# Altium schematic sheet LORA_NANO.SchDoc
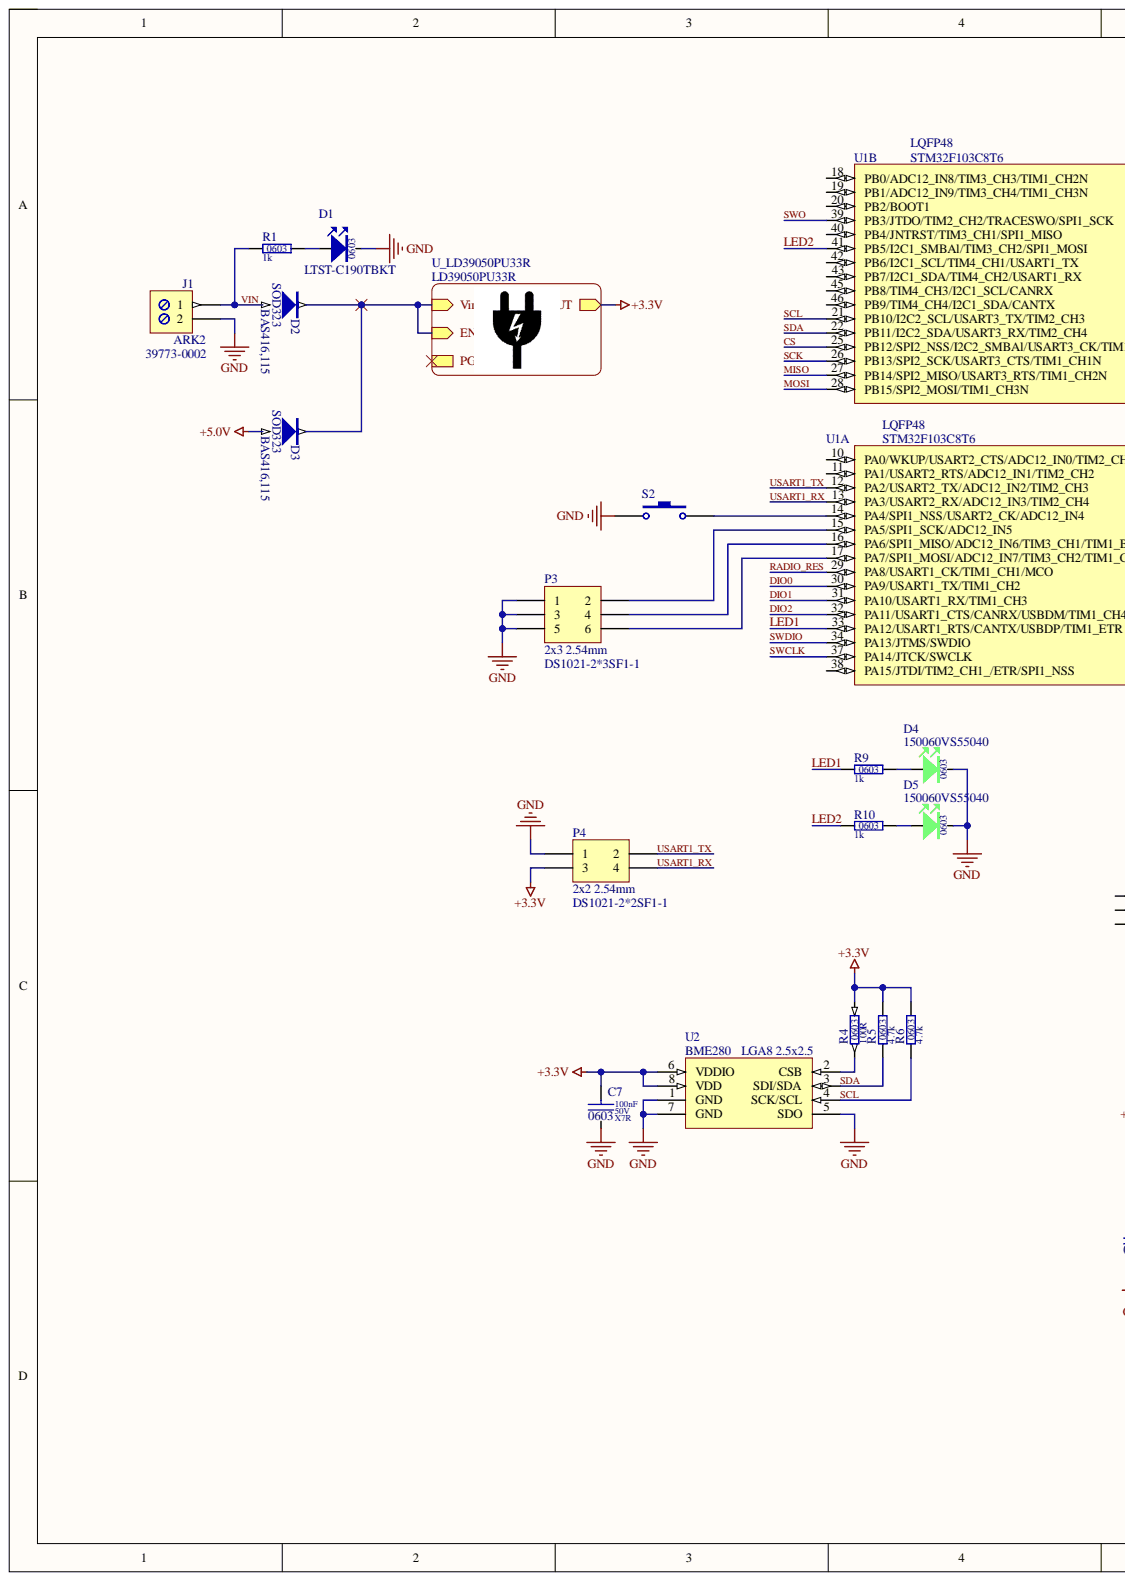
[diagram p0: LORA_NANO.SchDoc, left]
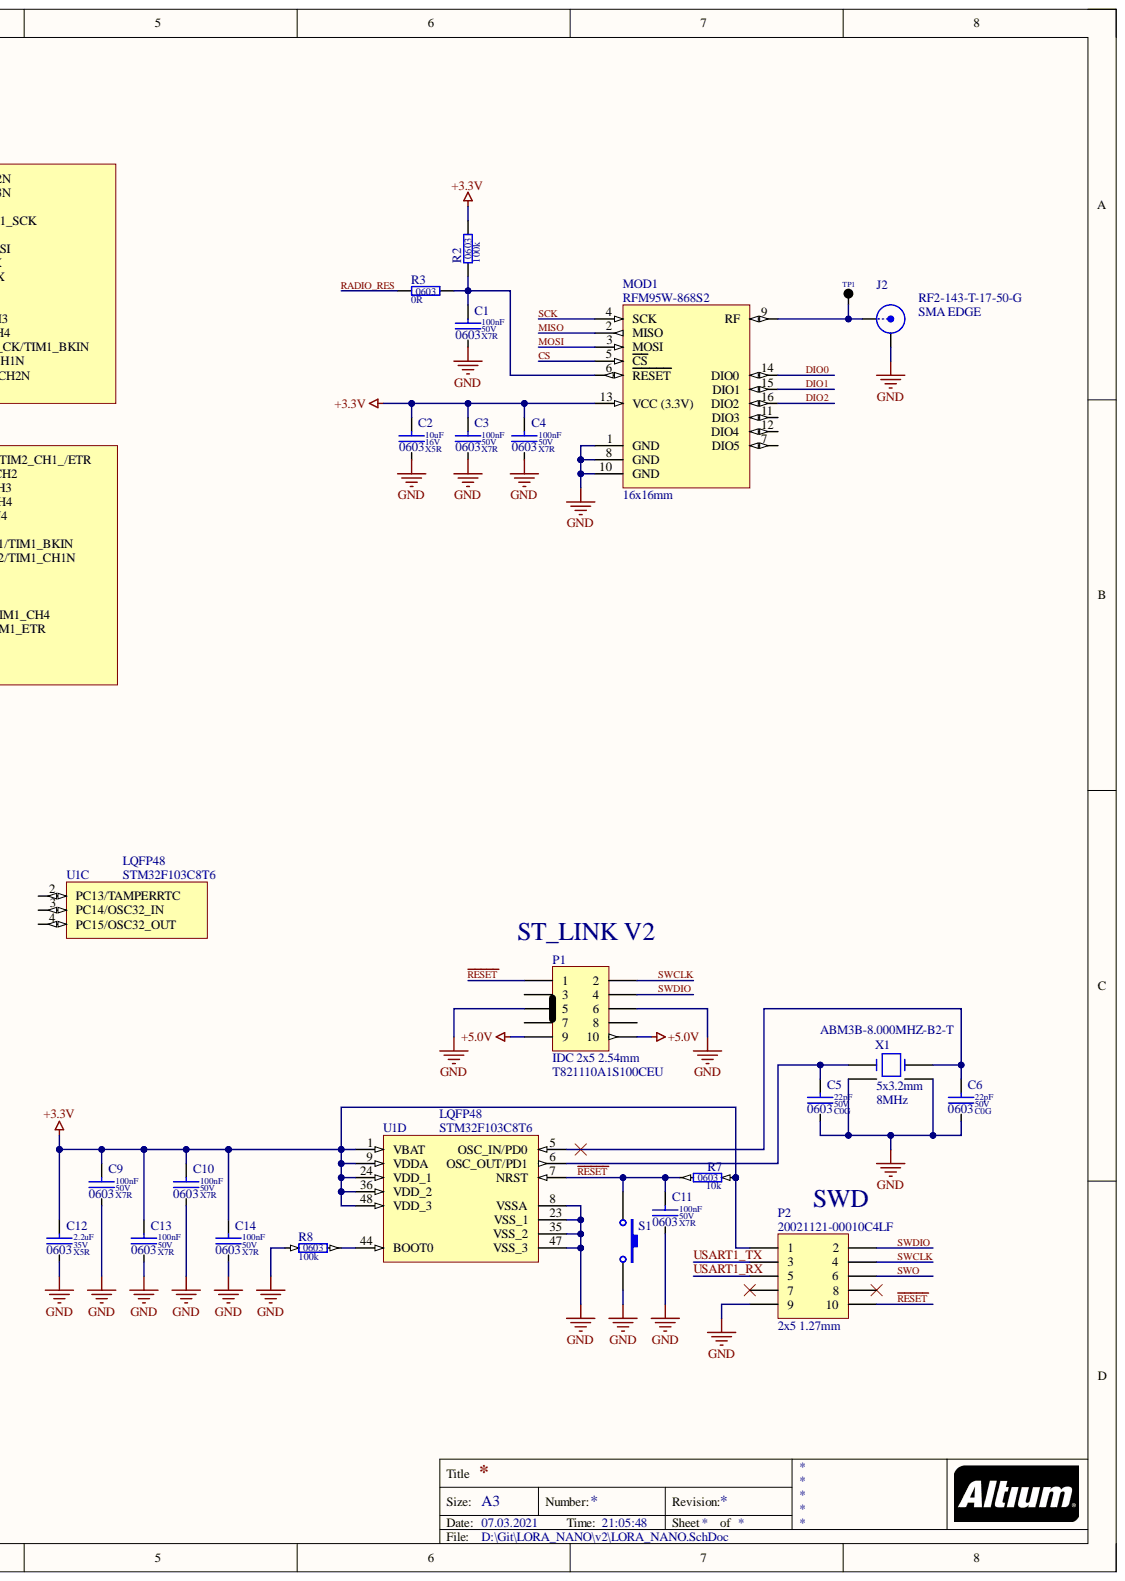
[diagram p1: LORA_NANO.SchDoc, right]

## Parts
C1  value 100nF  Dielectric: X7R  SCH_Footprint: 0603  Voltage: 50V  [C_0603_1608]  (hidden comment: Ceramic X7R)
C2  value 10uF  Dielectric: X5R  SCH_Footprint: 0603  Voltage: 16V  [C_0603_1608]  (hidden comment: Ceramic X5R)
C3  value 100nF  Dielectric: X7R  SCH_Footprint: 0603  Voltage: 50V  [C_0603_1608]  (hidden comment: Ceramic X7R)
C4  value 100nF  Dielectric: X7R  SCH_Footprint: 0603  Voltage: 50V  [C_0603_1608]  (hidden comment: Ceramic X7R)
C5  value 22pF  Dielectric: C0G  SCH_Footprint: 0603  Voltage: 50V  [C_0603_1608]  (hidden comment: Ceramic C0G)
C6  value 22pF  Dielectric: C0G  SCH_Footprint: 0603  Voltage: 50V  [C_0603_1608]  (hidden comment: Ceramic C0G)
C7  value 100nF  Dielectric: X7R  SCH_Footprint: 0603  Voltage: 50V  [C_0603_1608]  (hidden comment: Ceramic X7R)
C9  value 100nF  Dielectric: X7R  SCH_Footprint: 0603  Voltage: 50V  [C_0603_1608]  (hidden comment: Ceramic X7R)
C10  value 100nF  Dielectric: X7R  SCH_Footprint: 0603  Voltage: 50V  [C_0603_1608]  (hidden comment: Ceramic X7R)
C11  value 100nF  Dielectric: X7R  SCH_Footprint: 0603  Voltage: 50V  [C_0603_1608]  (hidden comment: Ceramic X7R)
C12  value 2.2uF  Dielectric: X5R  SCH_Footprint: 0603  Voltage: 35V  [C_0603_1608]  (hidden comment: Ceramic X5R)
C13  value 100nF  Dielectric: X7R  SCH_Footprint: 0603  Voltage: 50V  [C_0603_1608]  (hidden comment: Ceramic X7R)
C14  value 100nF  Dielectric: X7R  SCH_Footprint: 0603  Voltage: 50V  [C_0603_1608]  (hidden comment: Ceramic X7R)
D1  value LTST-C190TBKT  Manufacturer Part Number: LTST-C190TBKT  SCH_Footprint: 0603  [OL_0603_1608]  (hidden comment: Blue Led)
D2  BAS416  value BAS416,115  Manufacturer Part Number: BAS416,115  [SOD323]
D3  BAS416  value BAS416,115  Manufacturer Part Number: BAS416,115  [SOD323]
D4  value 150060VS55040  Manufacturer Part Number: 150060VS55040  SCH_Footprint: 0603  [OL_0603_1608]  (hidden comment: Green LED 0603)
D5  value 150060VS55040  Manufacturer Part Number: 150060VS55040  SCH_Footprint: 0603  [OL_0603_1608]  (hidden comment: Green LED 0603)
J1  ARK2  value 39773-0002  Manufacturer Part Number: 39773-0002  [J_ARK2_2.54]
J2  SMA EDGE  value RF2-143-T-17-50-G  Manufacturer Part Number: RF2-143-T-17-50-G  [J_SMA_EDGE_6.25]
MOD1  value RFM95W-868S2  Manufacturer Part Number: RFM95W-868S2  SCH_Footprint: 16x16mm  [MOD_RFM95]  (hidden comment: RFM95(W) - Low Power Long Range Transceiver Module + LoRa)
P1  IDC  value T821110A1S100CEU  Manufacturer Part Number: T821110A1S100CEU  SCH_Footprint: IDC 2x5 2.54mm  [P_IDC_2x5_2.54]
P2  value 20021121-00010C4LF  Manufacturer Part Number: 20021121-00010C4LF  SCH_Footprint: 2x5 1.27mm  [P_HEADER_2x5_1.27]  (hidden comment: Jtag)
P3  value DS1021-2*3SF1-1  Manufacturer Part Number: DS1021-2*3SF1-1  SCH_Footprint: 2x3 2.54mm  [P_HEADER_2x3_2.54]  (hidden comment: Goldpin male)
P4  value DS1021-2*2SF1-1  Manufacturer Part Number: DS1021-2*2SF1-1  SCH_Footprint: 2x2 2.54mm  [P_HEADER_2x2_2.54]  (hidden comment: Goldpin male)
R1  value 1k  SCH_Footprint: 0603  [R_0603_1608]  (hidden comment: Resistor)
R2  value 100k  SCH_Footprint: 0603  [R_0603_1608]  (hidden comment: Resistor)
R3  value 0R  SCH_Footprint: 0603  [R_0603_1608]  (hidden comment: Resistor)
R4  value 100R  SCH_Footprint: 0603  [R_0603_1608]  (hidden comment: Resistor)
R5  value 4.7k  SCH_Footprint: 0603  [R_0603_1608]  (hidden comment: Resistor)
R6  value 4.7k  SCH_Footprint: 0603  [R_0603_1608]  (hidden comment: Resistor)
R7  value 10k  SCH_Footprint: 0603  [R_0603_1608]  (hidden comment: Resistor)
R8  value 100k  SCH_Footprint: 0603  [R_0603_1608]  (hidden comment: Resistor)
R9  value 1k  SCH_Footprint: 0603  [R_0603_1608]  (hidden comment: Resistor)
R10  value 1k  SCH_Footprint: 0603  [R_0603_1608]  (hidden comment: Resistor)
S1  3x6mm  [S_TACTSWITCH3x6]  (value not drawn)
S2  3x6mm  [S_TACTSWITCH3x6]  (value not drawn)
TP1  Single pin  [TP_1PIN]  (value not drawn)
U1  STM32F103C8T6  SCH_Footprint: LQFP48  [LQFP48_7x7]  (parts A,B,C,D)
U2  value BME280  Manufacturer Part Number: BME280  SCH_Footprint: LGA8 2.5x2.5  [LGA8_2.5x2.5]  (hidden comment: Combined humidity and pressure sensor)
X1  8MHz  Manufacturer Part Number: ABM3B-8.000MHZ-B2-T  SCH_Footprint: 5x3.2mm  [X_ABM3B_5X3X11]

## Nets
+3.3V: C2.1 C3.1 C4.1 C7.1 C9.1 C10.1 C12.1 C13.1 C14.1 MOD1.13(VCC_(3.3V)) P2.1 P4.3 R2.2 R4.2 R5.2 R6.2 R7.1 U1.1(VBAT) U1.9(VDDA) U1.24(VDD_1) U1.36(VDD_2) U1.48(VDD_3) U2.6(VDDIO) U2.8(VDD)
+5.0V: D3.2 P1.9 P1.10
GND: C1.2 C2.2 C3.2 C4.2 C5.2 C6.2 C7.2 C9.2 C10.2 C11.2 C12.2 C13.2 C14.2 D1.1 D4.1 D5.1 J1.2 J2.2 MOD1.1(GND) MOD1.8(GND) MOD1.10(GND) P1.5 P1.6 P2.9 P3.1 P3.3 P3.5 P4.1 R8.1 S1.2 S2.1 U1.8(VSSA) U1.23(VSS_1) U1.35(VSS_2) U1.47(VSS_3) U2.1(GND) U2.5(SDO) U2.7(GND) X1.2 X1.4
CS: MOD1.5(CS) U1.25(PB12/SPI2_NSS/I2C2_SMBAl/USART3_CK/TIM1_BKIN)
DIO0: MOD1.14(DIO0) U1.30(PA9/USART1_TX/TIM1_CH2)
DIO1: MOD1.15(DIO1) U1.31(PA10/USART1_RX/TIM1_CH3)
DIO2: MOD1.16(DIO2) U1.32(PA11/USART1_CTS/CANRX/USBDM/TIM1_CH4)
LED1: R9.1 U1.33(PA12/USART1_RTS/CANTX/USBDP/TIM1_ETR)
LED2: R10.1 U1.41(PB5/I2C1_SMBAl/TIM3_CH2/SPI1_MOSI)
MISO: MOD1.2(MISO) U1.27(PB14/SPI2_MISO/USART3_RTS/TIM1_CH2N)
MOSI: MOD1.3(MOSI) U1.28(PB15/SPI2_MOSI/TIM1_CH3N)
~{RESET}: C11.1 P1.1 P2.10 R7.2 S1.1 U1.7(NRST)
RADIO_RES: R3.1 U1.29(PA8/USART1_CK/TIM1_CH1/MCO)
SCK: MOD1.4(SCK) U1.26(PB13/SPI2_SCK/USART3_CTS/TIM1_CH1N)
SCL: R6.1 U1.21(PB10/I2C2_SCL/USART3_TX/TIM2_CH3) U2.4(SCK/SCL)
SDA: R5.1 U1.22(PB11/I2C2_SDA/USART3_RX/TIM2_CH4) U2.3(SDI/SDA)
SWCLK: P1.2 P2.4 U1.37(PA14/JTCK/SWCLK)
SWDIO: P1.4 P2.2 U1.34(PA13/JTMS/SWDIO)
SWO: P2.6 U1.39(PB3/JTDO/TIM2_CH2/TRACESWO/SPI1_SCK)
USART1_RX: P2.5 P4.4 U1.13(PA3/USART2_RX/ADC12_IN3/TIM2_CH4)
USART1_TX: P2.3 P4.2 U1.12(PA2/USART2_TX/ADC12_IN2/TIM2_CH3)
VIN: D2.2 J1.1 R1.1
NetC1_1: C1.1 MOD1.6(RESET) R2.1 R3.2
NetC5_1: C5.1 U1.6(OSC_OUT/PD1) X1.3
NetC6_1: C6.1 U1.5(OSC_IN/PD0) X1.1
NetD1_2: D1.2 R1.2
NetD2_1: D2.1 D3.1
NetD4_2: D4.2 R9.2
NetD5_2: D5.2 R10.2
NetJ2_1: J2.1 MOD1.9(RF) TP1.1
NetMOD1_7: MOD1.7(DIO5)
NetMOD1_11: MOD1.11(DIO3)
NetMOD1_12: MOD1.12(DIO4)
NetP1_3: P1.3
NetP1_7: P1.7
NetP1_8: P1.8
NetP2_7: P2.7
NetP2_8: P2.8
NetP3_2: P3.2 U1.15(PA5/SPI1_SCK/ADC12_IN5)
NetP3_4: P3.4 U1.16(PA6/SPI1_MISO/ADC12_IN6/TIM3_CH1/TIM1_BKIN)
NetP3_6: P3.6 U1.17(PA7/SPI1_MOSI/ADC12_IN7/TIM3_CH2/TIM1_CH1N)
NetR4_1: R4.1 U2.2(CSB)
NetR8_2: R8.2 U1.44(BOOT0)
NetS2_2: S2.2 U1.14(PA4/SPI1_NSS/USART2_CK/ADC12_IN4)
NetU1_2: U1.2(PC13/TAMPERRTC)
NetU1_3: U1.3(PC14/OSC32_IN)
NetU1_4: U1.4(PC15/OSC32_OUT)
NetU1_10: U1.10(PA0/WKUP/USART2_CTS/ADC12_IN0/TIM2_CH1_/ETR)
NetU1_11: U1.11(PA1/USART2_RTS/ADC12_IN1/TIM2_CH2)
NetU1_18: U1.18(PB0/ADC12_IN8/TIM3_CH3/TIM1_CH2N)
NetU1_19: U1.19(PB1/ADC12_IN9/TIM3_CH4/TIM1_CH3N)
NetU1_20: U1.20(PB2/BOOT1)
NetU1_38: U1.38(PA15/JTDI/TIM2_CH1_/ETR/SPI1_NSS)
NetU1_40: U1.40(PB4/JNTRST/TIM3_CH1/SPI1_MISO)
NetU1_42: U1.42(PB6/I2C1_SCL/TIM4_CH1/USART1_TX)
NetU1_43: U1.43(PB7/I2C1_SDA/TIM4_CH2/USART1_RX)
NetU1_45: U1.45(PB8/TIM4_CH3/I2C1_SCL/CANRX)
NetU1_46: U1.46(PB9/TIM4_CH4/I2C1_SDA/CANTX)
PG:

## Library data of the parts
C1 C3 C4 C7 C9-C11 C13 C14 = C_100nF_50V_X7R_0603_5: Capacitor; Manufacturer: Samsung; Manufacturer Part Number: CL10B104JB8NNNC; Database Table Name: Capacitors; Tolerance (%): 5; Component Kind: Standard; HelpURL: http://www.tme.eu/pl/Document/4a42202b32dab16128fe107dd69598cc/samsung-chip-cap.pdf; Library Name: Library.DBLib; Part Number: C_100nF_50V_X7R_0603_5; Supplier 1: TME; Supplier 2: RS Components; Supplier 3: Farnell; Supplier 4: Mouser; Supplier 5: Digi-Key; Supplier Part Number 1: CL10B104JB8NNNC
  C1 C3 C4 C7: Supplier 6: LCSC
C2 = C_10uF_16V_X5R_0603_20: Capacitor; Manufacturer: Samsung Electro-Mechanics; Manufacturer Part Number: CL10A106MO8NQNC; Database Table Name: Capacitors; Tolerance (%): 20; Component Kind: Standard; HelpURL: http://www.tme.eu/pl/Document/4a42202b32dab16128fe107dd69598cc/samsung-chip-cap.pdf; Library Name: Library.DBLib; Part Number: C_10uF_16V_X5R_0603_20; Supplier 1: TME; Supplier 2: RS Components; Supplier 3: Farnell; Supplier 4: Mouser; Supplier 5: Digi-Key; Supplier 6: LCSC; Supplier Part Number 1: CL10A106MO8NQNC
C5 C6 = C_22pF_50V_C0G_0603_5: Capacitor; Manufacturer: AVX; Manufacturer Part Number: 06035A220JAT2A; Database Table Name: Capacitors; Tolerance (%): 5; Component Kind: Standard; HelpURL: https://datasheet.octopart.com/06035A220JAT2A-AVX-datasheet-9883399.pdf; Library Name: Library.DBLib; Part Number: C_22pF_50V_C0G_0603_5; Supplier 1: TME; Supplier 2: RS Components; Supplier 3: Farnell; Supplier 4: Mouser; Supplier 5: Digi-Key; Supplier Part Number 2: 1349628; Supplier Part Number 3: 2280861; Supplier Part Number 4: 581-06035A220J; Supplier Part Number 5: 478-1167-1-ND
C12 = C_2.2uF_35V_X5R_0603_10: Capacitor; Manufacturer: Murata; Manufacturer Part Number: GRM188R6YA225KA12D; Database Table Name: Capacitors; Tolerance (%): 10; Component Kind: Standard; HelpURL: https://datasheet.octopart.com/GRM188R6YA225KA12D-Murata-datasheet-66077095.pdf; Library Name: Library.DBLib; Part Number: C_2.2uF_35V_X5R_0603_10; Supplier 1: TME; Supplier 2: RS Components; Supplier 3: Farnell; Supplier 4: Mouser; Supplier 5: Digi-Key; Supplier Part Number 2: 8151358; Supplier Part Number 3: 2611926; Supplier Part Number 4: 81-GRM188R6YA225KA2D; Supplier Part Number 5: 490-7204-1-ND
D1 = OL_B_0603: LED_BLUE; Manufacturer: Lite-On; Database Table Name: Optoelectronics; Component Kind: Standard; HelpURL: https://datasheet.octopart.com/LTST-C190TBKT-Lite-On-datasheet-158557.pdf; Library Name: Library.DBLib; Part Number: OL_B_0603; Supplier 1: TME; Supplier 2: RS Components; Supplier 3: Farnell; Supplier 4: Mouser; Supplier 5: Digi-Key; Supplier 6: LCSC; Supplier Part Number 1: LTST-C190TBKT; Supplier Part Number 2: 6920994P; Supplier Part Number 4: 859-LTST-C190TBKT; Supplier Part Number 5: 160-1646-1-ND
D2 D3 = D_BAS416_0A5_75V_SOD323: Manufacturer: Nexperia; Current: 0.5A; Database Table Name: Diodes; Voltage: 75V; Component Kind: Standard; HelpURL: https://assets.nexperia.com/documents/data-sheet/BAS416.pdf; Library Name: Library.DBLib; Part Number: D_BAS416_0A5_75V_SOD323; SCH_Footprint: SOD323; Supplier 1: TME; Supplier 2: RS Components; Supplier 3: Farnell; Supplier 4: Mouser; Supplier 5: Digi-Key; Supplier 6: LCSC; Supplier Part Number 1: BAS416.115; Supplier Part Number 2: 508-286; Supplier Part Number 3: 2319285; Supplier Part Number 4: 771-BAS416-T/R; Supplier Part Number 5: 1727-4807-1-ND
D4 D5 = OL_G_0603_150060VS55040: LED GREEN; Manufacturer: Wurth; Database Table Name: Optoelectronics; Component Kind: Standard; HelpURL: https://datasheet.octopart.com/150060VS55040-W%C3%BCrth-Elektronik-datasheet-140170128.pdf; Library Name: Library.DBLib; Part Number: OL_G_0603_150060VS55040; Supplier 1: TME; Supplier 2: RS Components; Supplier 3: Farnell; Supplier 4: Mouser; Supplier 5: Digi-Key; Supplier 6: LCSC; Supplier Part Number 1: OSG80603C1E; Supplier Part Number 2: 1766157; Supplier Part Number 3: 2900765; Supplier Part Number 4: 710-150060VS55040; Supplier Part Number 5: 732-12017-1-ND
J1 = J_39773-0002: ARK Screw terminal, 2-Pin; Manufacturer: Molex; Database Table Name: Connectors; Component Kind: Standard; HelpURL: https://www.molex.com/webdocs/datasheets/pdf/en-us/0397730002_TERMINAL_BLOCKS.pdf; Library Name: Library.DBLib; Part Number: J_39773-0002; SCH_Footprint: ARK2; Supplier 1: TME; Supplier 2: RS Components; Supplier 3: Farnell; Supplier 4: Mouser; Supplier 5: Digi-Key; Supplier 6: LCSC; Supplier Part Number 4: 538-39773-0002; Supplier Part Number 5: 0397730002-ND
J2 = J_SMA_EDGE_6.25: SMA connector; Manufacturer: Adam Tech; Database Table Name: Connectors; Component Kind: Standard; HelpURL: http://www.tme.eu/pl/Document/5895dbb620da9c3499391e7670c4adea/RF2-143-T-17-50-G.pdf; Library Name: Library.DBLib; Part Number: J_SMA_EDGE_6.25; SCH_Footprint: SMA EDGE; Supplier 1: TME; Supplier 2: RS Components; Supplier 3: Farnell; Supplier 4: Mouser; Supplier 5: Digi-Key; Supplier 6: LCSC; Supplier Part Number 1: RF2-143-T-17-50-G
MOD1 = MOD_RFM95W-868S2: RFM95/96/97/98(W) - Low Power Long Range Transceiver Module; Manufacturer: HopeRF; Database Table Name: Modules; Component Kind: Standard; HelpURL: https://cdn.sparkfun.com/assets/learn_tutorials/8/0/4/RFM95_96_97_98W.pdf; Library Name: Library.DBLib; Part Number: MOD_RFM95W-868S2; Supplier 1: TME; Supplier 2: RS Components; Supplier 3: Farnell; Supplier 4: Mouser; Supplier 5: Digi-Key; Supplier 6: LCSC; Supplier Part Number 1: RFM95W-868S2; Supplier Part Number 2: 1251259; Supplier Part Number 3: 2759294; Supplier Part Number 4: 223-RFM95W-868S2; Supplier Part Number 5: RFM95W-868S2-ND
P1 = P_IDC_2x5_2.54: IDC Header; Manufacturer: Amphenol; Database Table Name: Connectors; Component Kind: Standard; HelpURL: https://www.tme.eu/Document/cda30bae7de800ca9be388e3f3476120/T821-1-08-S1.pdf; Library Name: Library.DBLib; Part Number: P_IDC_2x5_2.54; Supplier 1: TME; Supplier 2: RS Components; Supplier 3: Farnell; Supplier 4: Mouser; Supplier 5: Digi-Key; Supplier 6: LCSC; Supplier Part Number 1: T821-1-10-S1; Supplier Part Number 2: 832-3528; Supplier Part Number 3: 2215304
P2 = P_HEADER_2x5_1.27: Header, 10-Pin, Dual row; Manufacturer: FCI; Database Table Name: Connectors; Component Kind: Standard; Component Type: Standard; HelpURL: http://www.mouser.com/catalog/645/usd/1591.pdf; Library Name: Library.DBLib; Part Number: P_HEADER_2x5_1.27; Supplier 1: TME; Supplier 2: RS Components; Supplier 3: Farnell; Supplier 4: Mouser; Supplier 5: Digi-Key; Supplier Part Number 1: 20021121-00010C4LF; Supplier Part Number 2: 794-4584; Supplier Part Number 3: 1865279; Supplier Part Number 4: 649-202112100010C4LF; Supplier Part Number 5: 609-3695-1-ND
P3 = P_HEADER_2x3_2.54: Pin Header; Manufacturer: Connfly; Database Table Name: Connectors; Component Kind: Standard; HelpURL: https://www.tme.eu/Document/cc301e58a5da78cb543a94b5a5b7f06a/DS1021.pdf; Library Name: Library.DBLib; Part Number: P_HEADER_2x3_2.54; Supplier 1: TME; Supplier 2: RS Components; Supplier 3: Farnell; Supplier 4: Mouser; Supplier 5: Digi-Key; Supplier 6: LCSC; Supplier Part Number 1: ZL202-6G
P4 = P_HEADER_2x2_2.54: Pin Header; Manufacturer: Connfly; Database Table Name: Connectors; Component Kind: Standard; HelpURL: https://www.tme.eu/Document/cc301e58a5da78cb543a94b5a5b7f06a/DS1021.pdf; Library Name: Library.DBLib; Part Number: P_HEADER_2x2_2.54; Supplier 1: TME; Supplier 2: RS Components; Supplier 3: Farnell; Supplier 4: Mouser; Supplier 5: Digi-Key; Supplier 6: LCSC; Supplier Part Number 1: ZL202-4G
R1 R9 R10 = R_1k_0603_1: Manufacturer: Vishay; Manufacturer Part Number: CRCW06031K00FKEA; Database Table Name: Resistors; Power: 100mW; Tolerance: 1%; Voltage: 75V; Component Kind: Standard; HelpURL: http://www.farnell.com/datasheets/2310790.pdf?_ga=2.256888843.1690599162.1548417389-1379097396.1542296996&_gac=1.262631934.1547230258.Cj0KCQiAmuHhBRD0ARIsAFWyPwhX8HcvXnM3tA0ZBodZLzDIjH2ZxLpjT5LWo9bad_HPRbU8LfPq44UaAhEwEALw_wcB; Library Name: Library.DBLib; Part Number: R_1k_0603_1; Supplier 1: TME; Supplier 2: RS Components; Supplier 3: Farnell; Supplier 4: Mouser; Supplier 5: Digi-Key; Supplier 6: LCSC; Supplier Part Number 2: 678-9875P; Supplier Part Number 3: 1469740; Supplier Part Number 4: 71-CRCW0603-1.0K-E3; Supplier Part Number 5: 541-1.00KHCT-ND
R2 R8 = R_100k_0603_1: Manufacturer: Royalohm; Manufacturer Part Number: 0603SAF1003T5E; Database Table Name: Resistors; Power: 100mW; Tolerance: 1%; Voltage: 50V; Component Kind: Standard; HelpURL: http://www.tme.eu/pl/Document/b315665a56acbc42df513c99b390ad98/ROYALOHM-THICKFILM.pdf; Library Name: Library.DBLib; Part Number: R_100k_0603_1; Supplier 1: TME; Supplier 2: RS Components; Supplier 3: Farnell; Supplier 4: Mouser; Supplier 5: Digi-Key; Supplier Part Number 1: SMD0603-100K-1%; Supplier Part Number 4: 667-ERJ-3EKF1003V; Supplier Part Number 5: P100KHCT-ND
  R2: Supplier 6: LCSC
R3 = R_0R_0603_5: Manufacturer: Samsung; Manufacturer Part Number: RC1608J000CS; Database Table Name: Resistors; Power: 100mW; Tolerance: 5%; Voltage: 50V; Component Kind: Standard; HelpURL: http://www.samsungsem.com/kr/support/product-search/chip-resistor/RC1608J000CS.jsp; Library Name: Library.DBLib; Part Number: R_0R_0603_5; Supplier 1: TME; Supplier 2: RS Components; Supplier 3: Farnell; Supplier 4: Mouser; Supplier 5: Digi-Key; Supplier 6: LCSC; Supplier Part Number 5: 1276-3510-1-ND
R4 = R_100R_0603_1: Manufacturer: Yageo; Manufacturer Part Number: RC0603FR-07100RL; Database Table Name: Resistors; Power: 100mW; Tolerance: 1%; Voltage: 50V; Component Kind: Standard; HelpURL: http://www.tme.eu/en/Document/d2a72e545e5c8eb7bf2a04fa97535928/rc0603yageo.pdf; Library Name: Library.DBLib; Part Number: R_100R_0603_1; Supplier 1: TME; Supplier 2: RS Components; Supplier 3: Farnell; Supplier 4: Mouser; Supplier 5: Digi-Key; Supplier 6: LCSC; Supplier Part Number 1: RC0603FR-07100R; Supplier Part Number 3: 2131804; Supplier Part Number 4: 603-RC0603FR-07100RL; Supplier Part Number 5: 311-100HRCT-ND
R5 R6 = R_4.7k_0603_1: Manufacturer: Yageo; Manufacturer Part Number: RC0603FR-074K7L; Database Table Name: Resistors; Power: 100mW; Tolerance: 1%; Voltage: 75V; Component Kind: Standard; HelpURL: http://www.farnell.com/datasheets/2167052.pdf?_ga=2.156163131.1690599162.1548417389-1379097396.1542296996&_gac=1.18389067.1547230258.Cj0KCQiAmuHhBRD0ARIsAFWyPwhX8HcvXnM3tA0ZBodZLzDIjH2ZxLpjT5LWo9bad_HPRbU8LfPq44UaAhEwEALw_wcB; Library Name: Library.DBLib; Part Number: R_4.7k_0603_1; Supplier 1: TME; Supplier 2: RS Components; Supplier 3: Farnell; Supplier 4: Mouser; Supplier 5: Digi-Key; Supplier 6: LCSC; Supplier Part Number 1: RC0603FR-074K7; Supplier Part Number 3: 9238565; Supplier Part Number 4: 603-RC0603FR-074K7L; Supplier Part Number 5: 311-4.70KHRCT-ND
R7 = R_10k_0603_1: Manufacturer: Vishay; Manufacturer Part Number: CRCW060310K0FKTABC; Database Table Name: Resistors; Power: 100mW; Tolerance: 1%; Voltage: 75V; Component Kind: Standard; HelpURL: http://www.tme.eu/pl/Document/622e28308c06d160637f2b14751ba16b/Data%20Sheet%20CRCW_BCe3.pdf; Library Name: Library.DBLib; Part Number: R_10k_0603_1; Supplier 1: TME; Supplier 2: RS Components; Supplier 3: Farnell; Supplier 4: Mouser; Supplier 5: Digi-Key; Supplier Part Number 1: CRCW060310K0FKTABC; Supplier Part Number 4: 667-ERJ-3EKF1002V; Supplier Part Number 5: P10KGCT-ND
S1 S2 = S_TACTSWITCH: Tact Switch; Manufacturer: Ninigi; Manufacturer Part Number: TACTM-34N-F; Database Table Name: Passive Components; Component Kind: Standard; HelpURL: http://pl.ninigi.com/product/tactm-34n-f/pdf; Library Name: Library.DBLib; Part Number: S_TACTSWITCH; Supplier 1: TME; Supplier 2: RS Components; Supplier 3: Farnell; Supplier 4: Mouser; Supplier 5: Digi-Key; Supplier Part Number 1: TACTM-34N-F
TP1 = TP_1PIN: Testpoint; Database Table Name: Connectors; Component Kind: Standard; Library Name: Library.DBLib; Part Number: TP_1PIN; SCH_Footprint: Single; Supplier 1: TME; Supplier 2: RS Components; Supplier 3: Farnell; Supplier 4: Mouser; Supplier 5: Digi-Key; Supplier 6: LCSC
U1 = UP_STM32F103C8T6: Manufacturer: STMicroelectronics; Database Table Name: Microcontrollers; Component Kind: Standard; HelpURL: https://datasheet.octopart.com/STM32F103C8T6-STMicroelectronics-datasheet-48026265.pdf; Library Name: Library.DBLib; Manufacturer Part Number: STM32F103C8T6; Part Number: UP_STM32F103C8T6; Supplier 1: TME; Supplier 2: RS Components; Supplier 3: Farnell; Supplier 4: Mouser; Supplier 5: Digi-Key; Supplier 6: LCSC; Supplier Part Number 1: STM32F103C8T6; Supplier Part Number 2: 1023545; Supplier Part Number 3: 1447637; Supplier Part Number 4: 511-STM32F103C8T6; Supplier Part Number 5: 497-6063-ND; Value: STM32F103C8T6
U2 = U_BME280: Manufacturer: Bosch Tools; Database Table Name: IC Other; Component Kind: Standard; HelpURL: https://cdn-shop.adafruit.com/datasheets/BST-BME280_DS001-10.pdf; Library Name: Library.DBLib; Part Number: U_BME280; Supplier 1: TME; Supplier 2: RS Components; Supplier 3: Farnell; Supplier 4: Mouser; Supplier 5: Digi-Key; Supplier 6: LCSC; Supplier Part Number 4: 262-BME280; Supplier Part Number 5: 828-1063-1-ND
X1 = X_ABM3B-8.000MHZ-B2-T: Manufacturer: Abracon; Database Table Name: Crystals; Component Kind: Standard; HelpURL: https://datasheet.octopart.com/ABM3B-8.000MHZ-B2-T-Abracon-datasheet-12510201.pdf; Library Name: Library.DBLib; Part Number: X_ABM3B-8.000MHZ-B2-T; Supplier 1: TME; Supplier 2: RS Components; Supplier 3: Farnell; Supplier 4: Mouser; Supplier 5: Digi-Key; Supplier Part Number 1: ABM3B-8.000MHZ-B2; Supplier Part Number 2: 171-0644; Supplier Part Number 3: 2467818; Supplier Part Number 4: 815-ABM3B-8.0-B2-T; Supplier Part Number 5: 535-9720-1-ND; Value: 8MHz
